# Altium schematic sheet Fourth Band Filter.SchDoc
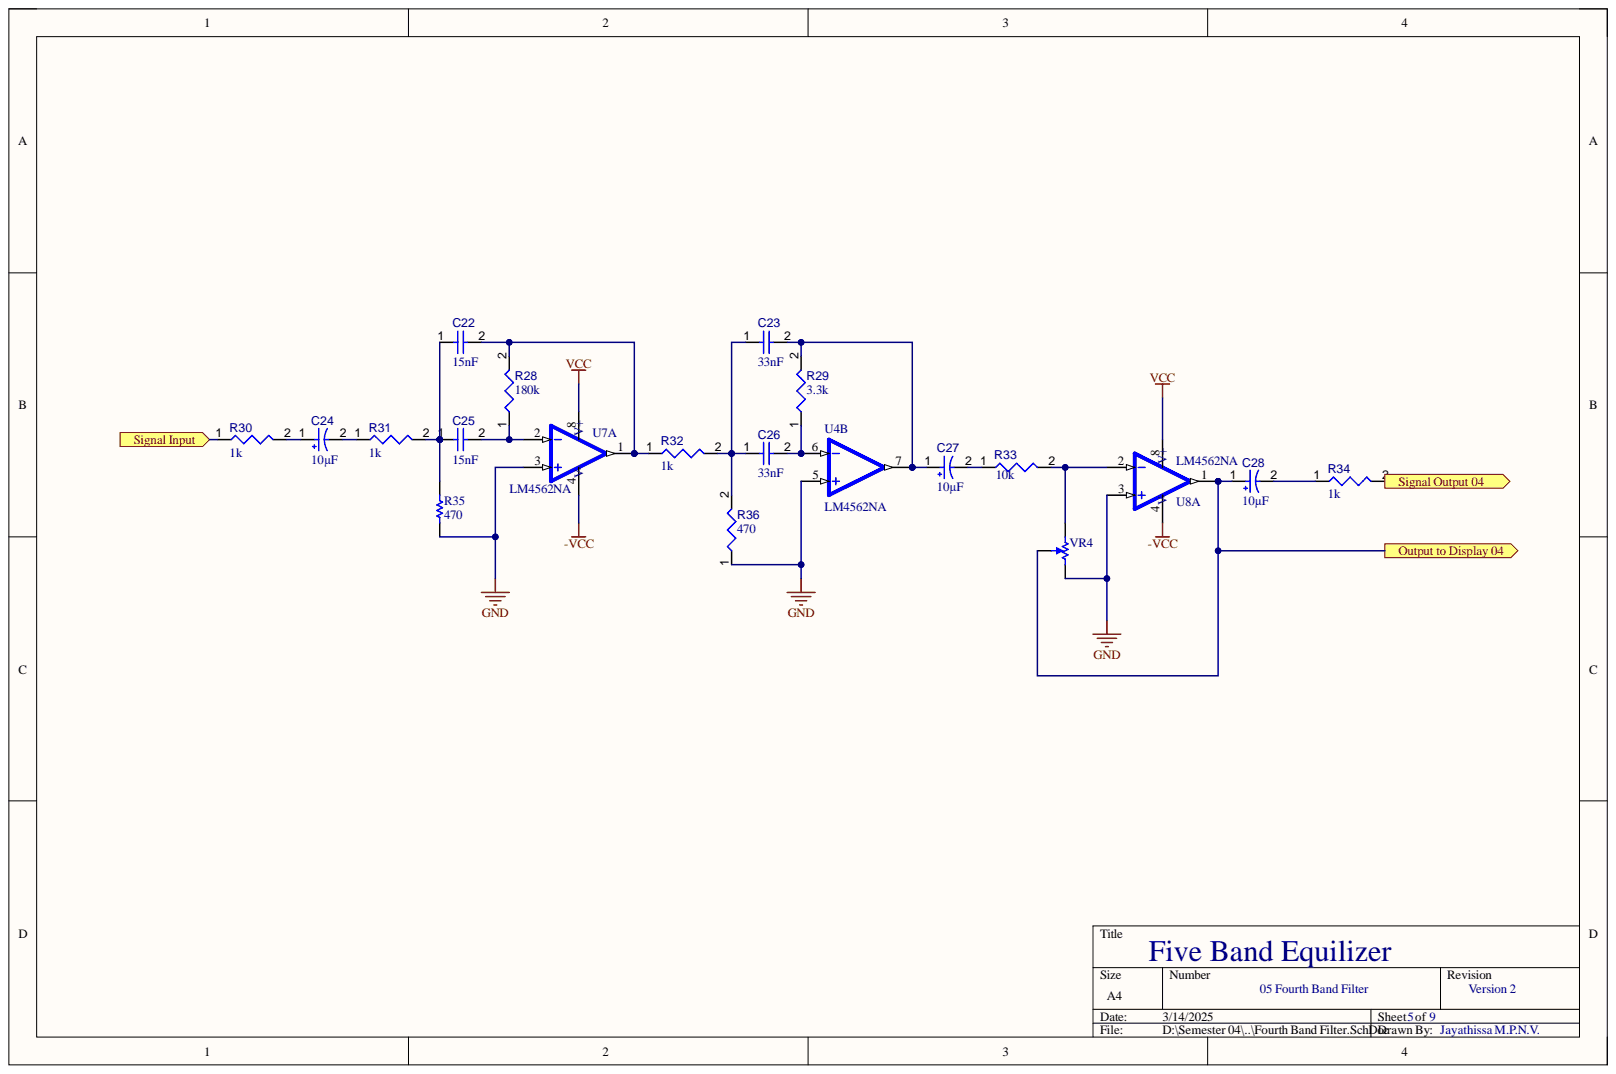
[diagram p0: Fourth Band Filter.SchDoc]

## Parts
C22  value 15nF  [CAPC1005X56X25NL10T15]  (hidden comment: Capacitor 6.8 nF +/- 10% 16 V 0402)
C23  value 33nF  [CAPC0402(1005)60_L]  (hidden comment: Capacitor 22nF +/-20% 10V 0402)
C24  value 10µF  [PNSC-6TPE150MAZB_L]  (hidden comment: Capacitor 6.8µF +/-20% 35V B2)
C25  value 15nF  [CAPC1005X56X25NL10T15]  (hidden comment: Capacitor 6.8 nF +/- 10% 16 V 0402)
C26  value 33nF  [CAPC0402(1005)60_L]  (hidden comment: Capacitor 22nF +/-20% 10V 0402)
C27  value 10µF  [PNSC-6TPE150MAZB_L]  (hidden comment: Capacitor 6.8µF +/-20% 35V B2)
C28  value 10µF  [PNSC-6TPE150MAZB_L]  (hidden comment: Capacitor 6.8µF +/-20% 35V B2)
R28  value 180k  [RESC0402(1005)_L]  (hidden comment: Resistor 232K +/-1 % 0402 63 mW)
R29  value 3.3k  [RESC0402(1005)_L]  (hidden comment: Resistor 47K5 +/-1 % 0402 63 mW)
R30  value 1k  [RESC0805(2012)_L]  (hidden comment: Resistor 1K +/-5% 0805 125 mW)
R31  value 1k  [RESC0402(1005)_L]  (hidden comment: Resistor 47K5 +/-1 % 0402 63 mW)
R32  value 1k  [RESC1005X40X25ML10T10]  (hidden comment: Resistor 15k +/-1% 0402 63 mW)
R33  value 10k  [RESC0402(1005)_L]  (hidden comment: Resistor 10K  +/-1 % 0402 63 mW)
R34  value 1k  [RESC0805(2012)_L]  (hidden comment: Resistor 1K +/-5% 0805 125 mW)
R35  value 470  [RESC1005X40X25ML05T10]  (hidden comment: Resistor 51k +/-5% 0402 63 mW)
R36  value 470  [RESC1005X40X25ML10T10]  (hidden comment: Resistor 15k +/-1% 0402 63 mW)
U4  LM4562NA  [DIP794W45P254L959H508Q8]  (part B)
U7  LM4562NA  [DIP794W45P254L959H508Q8]  (part A)
U8  LM4562NA  [DIP794W45P254L959H508Q8]  (part A)
VR4  3296W-1-102LF  [BOUR-3296W-1-3]  (value not drawn)

## Ports
Output to Display 04, Signal Input, Signal Output 04

## Nets
-VCC: U7.4 U8.4
GND: R35.1 R36.1 U4.5 U7.3(V-) U8.3(V-) VR4.2
VCC: U7.8 U8.8
Output to Display 04: C28.1 U8.1 VR4.3 ; port Output to Display 04
Signal Input: R30.1 ; port Signal Input
Signal Output 04: R34.2 ; port Signal Output 04
NetC22_1: C22.1 C25.1 R31.2 R35.2
NetC22_2: C22.2 R28.2 R32.1 U7.1
NetC23_1: C23.1 C26.1 R32.2 R36.2
NetC23_2: C23.2 C27.1 R29.2 U4.7
NetC24_1: C24.1 R30.2
NetC24_2: C24.2 R31.1
NetC25_2: C25.2 R28.1 U7.2
NetC26_2: C26.2 R29.1 U4.6
NetC27_2: C27.2 R33.1
NetC28_2: C28.2 R34.1
NetR33_2: R33.2 U8.2 VR4.1

## Library data of the parts
C22 C25 = CMP-001-00000-6: Chip Capacitor, 6.8 nF, +/- 10%, 16 V, 0402 (1005 Metric); Capacitor Type: Ceramic; Voltage Rating: 16V; Case/Package: 0402; Component Kind: Standard; Max Operating Temperature: 125°C; Min Operating Temperature: -55°C; Mounting Technology: SM; Pins: 2; RoHS Compliant: True; Tolerance: 10%; Library Name: Nirwan Jayathissa
C23 C26 = CMP-001-00062-3: Chip Capacitor, 22nF +/-20%, 10V, 0402, Thickness 0.6 mm; Tolerance: 10%; Case/Package: 0402; Component Kind: Standard; Max Operating Temperature: 85°C; Min Operating Temperature: -55°C; Mounting Technology: SMT; Pins: 2; Voltage Rating: 10V; Library Name: Nirwan Jayathissa
C24 C27 C28 = CMP-001-00059-3: Molded Tantalum Polymer Capacitor, 6.8 uF, +/- 20%, 35 V, -55 to 105 degC, 2-Pin SMD, RoHS, Tape and Reel; Case/Package: 1210; Component Kind: Standard; Max Operating Temperature: 105°C; Min Operating Temperature: -55°C; Mounting Technology: SMT; Pins: 2; Tolerance: 20%; Voltage Rating: 35V; Library Name: Nirwan Jayathissa
R28 = CMP-009-00127-3: Chip Resistor, 232 kOhms, +/-1 %, 63 mW, -55 to 155 degC, 0402; Power: 63mW; Tolerance: 1%; Case/Package: 0402; Component Kind: Standard; Pins: 2; Library Name: Nirwan Jayathissa
R29 R31 = CMP-009-00137-3: Chip Resistor, 47,5 kOhms, +/-1 %, 63 mW, -55 to 155 degC, 0402; Power: 63mW; Tolerance: 1%; Case/Package: 0402; Component Kind: Standard; Max Operating Temperature: 155°C; Min Operating Temperature: -55°C; Pins: 2; Library Name: Nirwan Jayathissa
R30 R34 = CMP-009-00118-3: Chip Resistor, 1 kOhms, +/-5%, 125 mW, -55 to 155 degC, 0805; Power: 125mW; Tolerance: 5%; Case/Package: 0805; Component Kind: Standard; Max Operating Temperature: 155°C; Min Operating Temperature: -55°C; Pins: 2; Library Name: Nirwan Jayathissa
R32 R36 = CMP-009-00026-5: Chip Resistor, 15 KOhm, +/- 1%, 0.063 W, -55 to 155 degC, 0402 (1005 Metric); Power: 63mW; Case/Package: 0402; Component Kind: Standard; Max Operating Temperature: 155°C; Min Operating Temperature: -55°C; Mounting Technology: SM; Pins: 2; RoHS Compliant: True; Tolerance: 1%; Library Name: Nirwan Jayathissa
R33 = CMP-009-00149-3: Chip Resistor, 10 kOhms, +/-1 %, 63 mW, -55 to 125 degC, 0402; Power: 63mW; Tolerance: 1%; Case/Package: 0402; Component Kind: Standard; Max Operating Temperature: 155°C; Min Operating Temperature: -55°C; Pins: 2; Library Name: Nirwan Jayathissa
R35 = CMP-009-00000-5: Chip Resistor, 51 KOhm, +/- 5%, 0.063 W, -55 to 155 degC, 0402 (1005 Metric); Power: 63mW; Case/Package: 0402; Component Kind: Standard; Max Operating Temperature: 155°C; Min Operating Temperature: -55°C; Mounting Technology: SM; Pins: 2; RoHS Compliant: True; Tolerance: 5%; Library Name: Nirwan Jayathissa
U4 U7 U8 = CMP-014-00002-1: LM4562 2 - Dual Channels per IC Audio Amplifier Evaluation Board; MF: Texas Instruments; Availability: In Stock; Case/Package: DIP-8 Texas Instruments; Check_prices: https://www.snapeda.com/parts/LM4562NA/Texas+Instruments/view-part/?ref=eda; Component Kind: Standard; Component Type: Standard; Design Item ID: LM4562NA; MP: LM4562NA; Pins: 8; Price: None; Purchase-URL: https://pricing.snapeda.com/search/part/LM4562NA/?ref=eda; SnapEDA_Link: https://www.snapeda.com/parts/LM4562NA/Texas+Instruments/view-part/?ref=snap; Source: LM4562NA.SchLib; Library Name: Nirwan Jayathissa
VR4 = CMP-009-00037-2: Square Trimpot(R) Trimming Potentiometer, 1000 Ohm, -55 to 125 degC, 3-Pin THD, RoHS, Tube; Manufacturer: Bourns; Power: 500mW; Resistance: 1k; Tolerance: 10%; Voltage Rating: 300V; Case/Package: 3296W-1-3; Component Kind: Standard; Composition: Potentiometer; Datasheet URL: http://www.bourns.com/docs/Product-Datasheets/3296.pdf; Datasheet Version: May-16; Manufacturer URL: http://www.bourns.com/; Max Operating Temperature: 150°C; Min Operating Temperature: -55°C; Mounting Technology: TH; Package Description: 3-Pin Through Hole Device, Body 9.53 x 4.83 mm, Pitch 2.54 mm; Package Quantity: 50; Package Reference: 3296W-1-3; Packaging: Rail/Tube; RoHS Compliant: True; Library Name: Nirwan Jayathissa
